# Altium schematic sheet RX.SchDoc(RX)
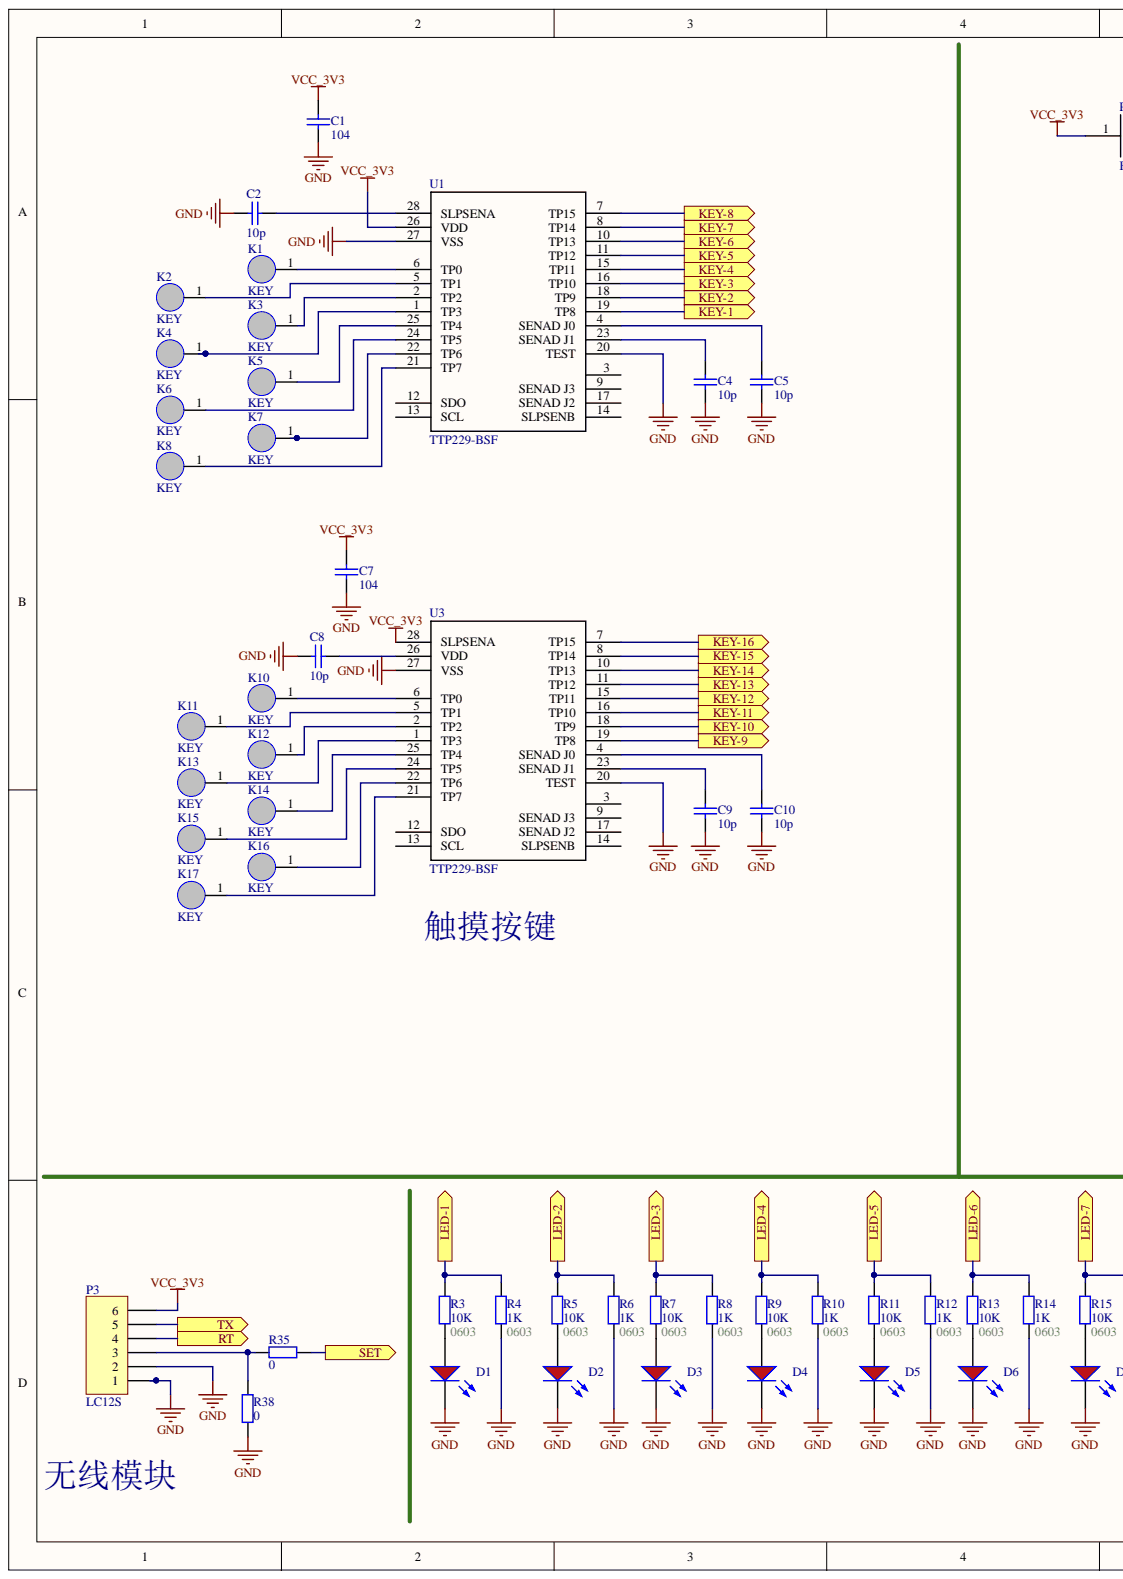
[diagram p0: RX.SchDoc, left]
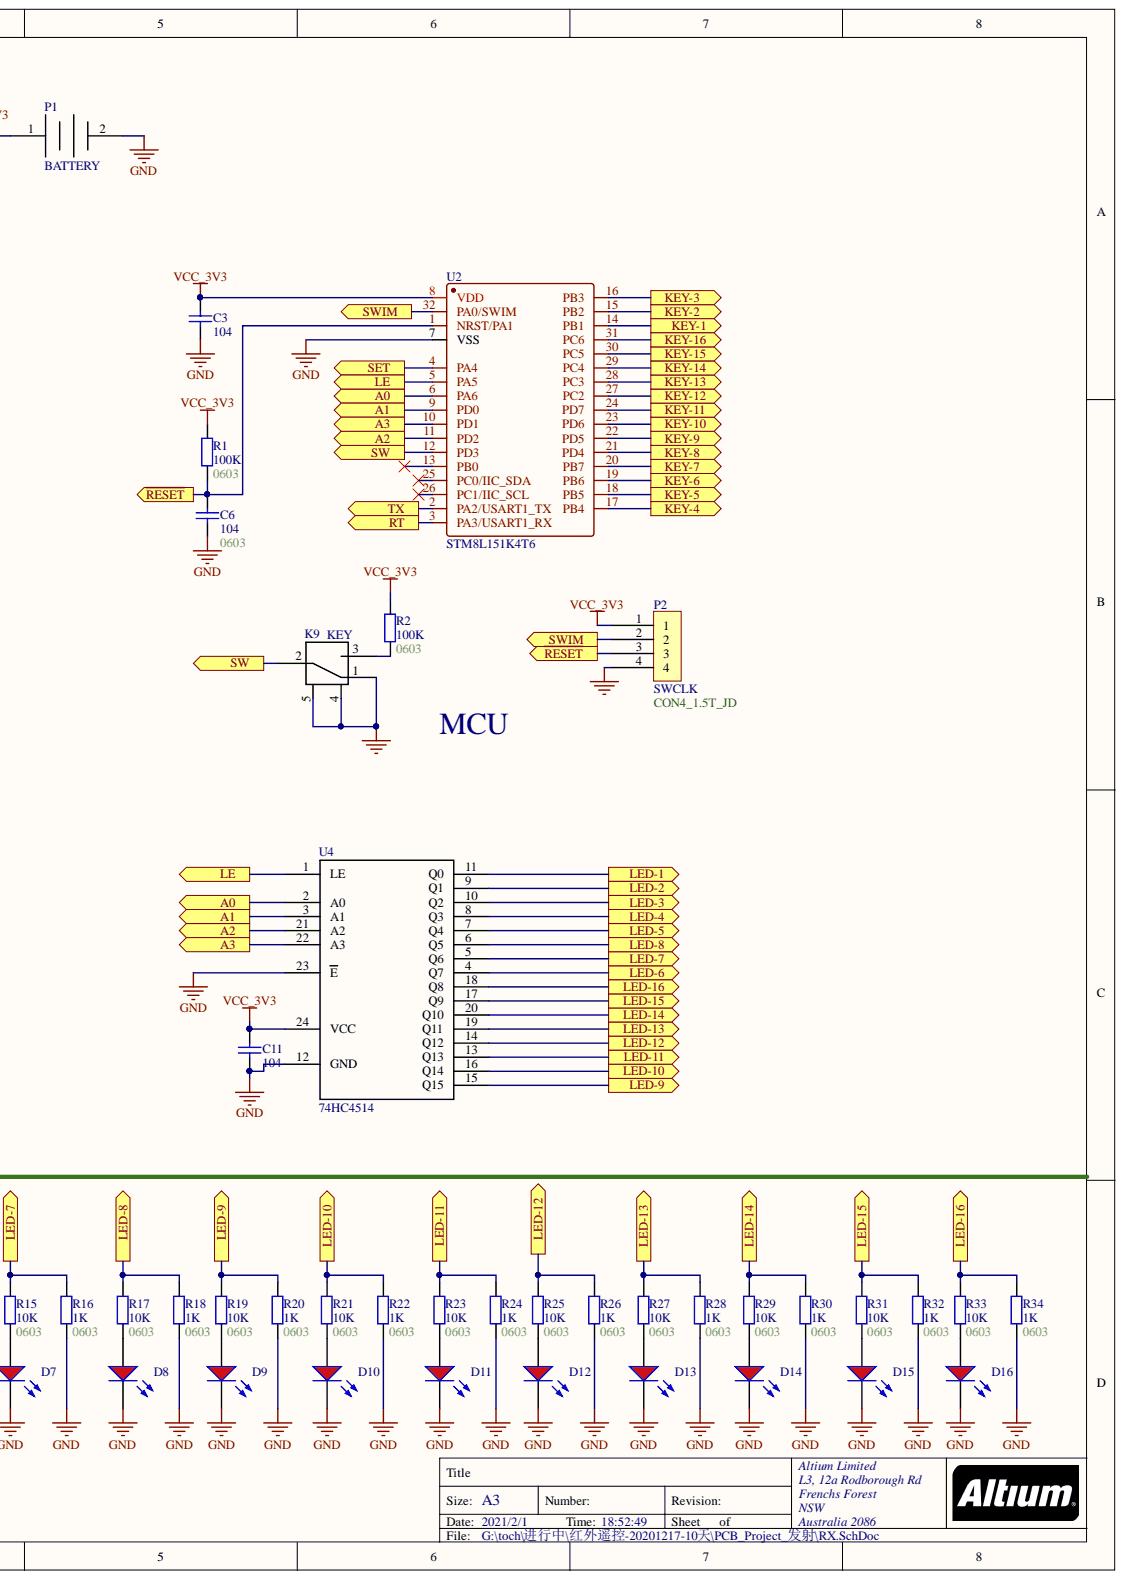
[diagram p1: RX.SchDoc, right]

## Parts
C1  104  [0603_C]
C2  10p  [0603_C]
C3  104  [0603_C]
C4  10p  [0603_C]
C5  10p  [0603_C]
C6  104  Package: 0603  [0603_C]
C7  104  [0603_C]
C8  10p  [0603_C]
C9  10p  [0603_C]
C10  10p  [0603_C]
C11  104  [0603_C]
D1  Package: 0603  [0603_LED_S1]  (hidden comment: 红灯 0603)
D2  Package: 0603  [0603_LED_S1]  (hidden comment: 红灯 0603)
D3  Package: 0603  [0603_LED_S1]  (hidden comment: 红灯 0603)
D4  Package: 0603  [0603_LED_S1]  (hidden comment: 红灯 0603)
D5  Package: 0603  [0603_LED_S1]  (hidden comment: 红灯 0603)
D6  Package: 0603  [0603_LED_S1]  (hidden comment: 红灯 0603)
D7  Package: 0603  [0603_LED_S1]  (hidden comment: 红灯 0603)
D8  Package: 0603  [0603_LED_S1]  (hidden comment: 红灯 0603)
D9  Package: 0603  [0603_LED_S1]  (hidden comment: 红灯 0603)
D10  Package: 0603  [0603_LED_S1]  (hidden comment: 红灯 0603)
D11  Package: 0603  [0603_LED_S1]  (hidden comment: 红灯 0603)
D12  Package: 0603  [0603_LED_S1]  (hidden comment: 红灯 0603)
D13  Package: 0603  [0603_LED_S1]  (hidden comment: 红灯 0603)
D14  Package: 0603  [0603_LED_S1]  (hidden comment: 红灯 0603)
D15  Package: 0603  [0603_LED_S1]  (hidden comment: 红灯 0603)
D16  Package: 0603  [0603_LED_S1]  (hidden comment: 红灯 0603)
K1  KEY  [key]
K2  KEY  [key]
K3  KEY  [key]
K4  KEY  [key]
K5  KEY  [key]
K6  KEY  [key]
K7  KEY  [key]
K8  KEY  [key]
K9  KEY  [SW]
K10  KEY  [key]
K11  KEY  [key]
K12  KEY  [key]
K13  KEY  [key]
K14  KEY  [key]
K15  KEY  [key]
K16  KEY  [key]
K17  KEY  [key]
P1  BATTERY  [2032]
P2  SWCLK  [xiazai]
P3  LC12S  [HDR1X6]
R1  100K  Package: 0603  [0603_R]
R2  100K  Package: 0603  [0603_R]
R3  10K  Package: 0603  [0603_R]
R4  1K  Package: 0603  [0603_R]
R5  10K  Package: 0603  [0603_R]
R6  1K  Package: 0603  [0603_R]
R7  10K  Package: 0603  [0603_R]
R8  1K  Package: 0603  [0603_R]
R9  10K  Package: 0603  [0603_R]
R10  1K  Package: 0603  [0603_R]
R11  10K  Package: 0603  [0603_R]
R12  1K  Package: 0603  [0603_R]
R13  10K  Package: 0603  [0603_R]
R14  1K  Package: 0603  [0603_R]
R15  10K  Package: 0603  [0603_R]
R16  1K  Package: 0603  [0603_R]
R17  10K  Package: 0603  [0603_R]
R18  1K  Package: 0603  [0603_R]
R19  10K  Package: 0603  [0603_R]
R20  1K  Package: 0603  [0603_R]
R21  10K  Package: 0603  [0603_R]
R22  1K  Package: 0603  [0603_R]
R23  10K  Package: 0603  [0603_R]
R24  1K  Package: 0603  [0603_R]
R25  10K  Package: 0603  [0603_R]
R26  1K  Package: 0603  [0603_R]
R27  10K  Package: 0603  [0603_R]
R28  1K  Package: 0603  [0603_R]
R29  10K  Package: 0603  [0603_R]
R30  1K  Package: 0603  [0603_R]
R31  10K  Package: 0603  [0603_R]
R32  1K  Package: 0603  [0603_R]
R33  10K  Package: 0603  [0603_R]
R34  1K  Package: 0603  [0603_R]
R35  0  [0603_R]
R38  0  [0603_R]
U1  TTP229-BSF  [TTP229-BSF]
U2  STM8L151K4T6  [STM8L151K4T6]
U3  TTP229-BSF  [TTP229-BSF]
U4  74HC4514  [74HC451]

## Ports
A0, A1, A2, A3, KEY-1, KEY-2, KEY-3, KEY-4, KEY-5, KEY-6, KEY-7, KEY-8, KEY-9, KEY-10, KEY-11, KEY-12, KEY-13, KEY-14, KEY-15, KEY-16, LE, LED-1, LED-2, LED-3, LED-4, LED-5, LED-6, LED-7, LED-8, LED-9, LED-10, LED-11, LED-12, LED-13, LED-14, LED-15, LED-16, RT, SET, SW, SWIM, TX, ~{RESET}

## Nets
GND: C1.1 C2.1 C3.1 C4.1 C5.1 C6.1 C7.1 C8.1 C9.1 C10.1 C11.1 D1.K D2.K D3.K D4.K D5.K D6.K D7.K D8.K D9.K D10.K D11.K D12.K D13.K D14.K D15.K D16.K K9.1 K9.4 K9.5 P1.2 P2.4 P3.1 P3.2 R4.1 R6.1 R8.1 R10.1 R12.1 R14.1 R16.1 R18.1 R20.1 R22.1 R24.1 R26.1 R28.1 R30.1 R32.1 R34.1 R38.1 U1.20(TEST) U1.27(VSS) U2.7(VSS) U3.20(TEST) U3.27(VSS) U4.12(GND) U4.23(E)
VCC_3V3: C1.2 C3.2 C7.2 C11.2 P1.1 P2.1 P3.6 R1.2 R2.2 U1.26(VDD) U2.8(VDD) U3.28(SLPSENA) U4.24(VCC)
A0: U2.6(PA6) U4.2(A0) ; port A0
A1: U2.9(PD0) U4.3(A1) ; port A1
A2: U2.11(PD2) U4.21(A2) ; port A2
A3: U2.10(PD1) U4.22(A3) ; port A3
KEY-1: U1.19(TP8) U2.14(PB1) ; port KEY-1
KEY-2: U1.18(TP9) U2.15(PB2) ; port KEY-2
KEY-3: U1.16(TP10) U2.16(PB3) ; port KEY-3
KEY-4: U1.15(TP11) U2.17(PB4) ; port KEY-4
KEY-5: U1.11(TP12) U2.18(PB5) ; port KEY-5
KEY-6: U1.10(TP13) U2.19(PB6) ; port KEY-6
KEY-7: U1.8(TP14) U2.20(PB7) ; port KEY-7
KEY-8: U1.7(TP15) U2.21(PD4) ; port KEY-8
KEY-9: U2.22(PD5) U3.19(TP8) ; port KEY-9
KEY-10: U2.23(PD6) U3.18(TP9) ; port KEY-10
KEY-11: U2.24(PD7) U3.16(TP10) ; port KEY-11
KEY-12: U2.27(PC2) U3.15(TP11) ; port KEY-12
KEY-13: U2.28(PC3) U3.11(TP12) ; port KEY-13
KEY-14: U2.29(PC4) U3.10(TP13) ; port KEY-14
KEY-15: U2.30(PC5) U3.8(TP14) ; port KEY-15
KEY-16: U2.31(PC6) U3.7(TP15) ; port KEY-16
LE: U2.5(PA5) U4.1(LE) ; port LE
LED-1: R3.2 R4.2 U4.11(Q0) ; port LED-1
LED-2: R5.2 R6.2 U4.9(Q1) ; port LED-2
LED-3: R7.2 R8.2 U4.10(Q2) ; port LED-3
LED-4: R9.2 R10.2 U4.8(Q3) ; port LED-4
LED-5: R11.2 R12.2 U4.7(Q4) ; port LED-5
LED-6: R13.2 R14.2 U4.4(Q7) ; port LED-6
LED-7: R15.2 R16.2 U4.5(Q6) ; port LED-7
LED-8: R17.2 R18.2 U4.6(Q5) ; port LED-8
LED-9: R19.2 R20.2 U4.15(Q15) ; port LED-9
LED-10: R21.2 R22.2 U4.16(Q14) ; port LED-10
LED-11: R23.2 R24.2 U4.13(Q13) ; port LED-11
LED-12: R25.2 R26.2 U4.14(Q12) ; port LED-12
LED-13: R27.2 R28.2 U4.19(Q11) ; port LED-13
LED-14: R29.2 R30.2 U4.20(Q10) ; port LED-14
LED-15: R31.2 R32.2 U4.17(Q9) ; port LED-15
LED-16: R33.2 R34.2 U4.18(Q8) ; port LED-16
~{RESET}: C6.2 P2.3 R1.1 U2.1(NRST/PA1) ; port ~{RESET}
RT: P3.4 U2.3(PA3/USART1_RX) ; port RT
SET: R35.2 U2.4(PA4) ; port SET
SW: K9.2 U2.12(PD3) ; port SW
SWIM: P2.2 U2.32(PA0/SWIM) ; port SWIM
TX: P3.5 U2.2(PA2/USART1_TX) ; port TX
NetC2_2: C2.2 U1.28(SLPSENA)
NetC4_2: C4.2 U1.23(SENAD_J1)
NetC5_2: C5.2 U1.4(SENAD_J0)
NetC8_2: C8.2 U3.26(VDD)
NetC9_2: C9.2 U3.23(SENAD_J1)
NetC10_2: C10.2 U3.4(SENAD_J0)
NetD1_A: D1.A R3.1
NetD2_A: D2.A R5.1
NetD3_A: D3.A R7.1
NetD4_A: D4.A R9.1
NetD5_A: D5.A R11.1
NetD6_A: D6.A R13.1
NetD7_A: D7.A R15.1
NetD8_A: D8.A R17.1
NetD9_A: D9.A R19.1
NetD10_A: D10.A R21.1
NetD11_A: D11.A R23.1
NetD12_A: D12.A R25.1
NetD13_A: D13.A R27.1
NetD14_A: D14.A R29.1
NetD15_A: D15.A R31.1
NetD16_A: D16.A R33.1
NetK1_1: K1.1 U1.6(TP0)
NetK2_1: K2.1 U1.5(TP1)
NetK3_1: K3.1 U1.2(TP2)
NetK4_1: K4.1 U1.1(TP3)
NetK5_1: K5.1 U1.25(TP4)
NetK6_1: K6.1 U1.24(TP5)
NetK7_1: K7.1 U1.22(TP6)
NetK8_1: K8.1 U1.21(TP7)
NetK9_3: K9.3 R2.1
NetK10_1: K10.1 U3.6(TP0)
NetK11_1: K11.1 U3.5(TP1)
NetK12_1: K12.1 U3.2(TP2)
NetK13_1: K13.1 U3.1(TP3)
NetK14_1: K14.1 U3.25(TP4)
NetK15_1: K15.1 U3.24(TP5)
NetK16_1: K16.1 U3.22(TP6)
NetK17_1: K17.1 U3.21(TP7)
NetP3_3: P3.3 R35.1 R38.2

Not connected: U1 3 9(SENAD_J3) 12(SDO) 13(SCL) 14(SLPSENB) 17(SENAD_J2); U2 13(PB0) 25(PC0/IIC_SDA) 26(PC1/IIC_SCL); U3 3 9(SENAD_J3) 12(SDO) 13(SCL) 14(SLPSENB) 17(SENAD_J2)

## Library data of the parts
C1 C2 C4 C5 C7-C11 = 0603 100nF (104) 10% 50V: 贴片电容; SuppliersPartNumber: C14663; Supplier: LC; Package: 0603; Library Name: Miscellaneous Devices LC.IntLib; ComponentLink1URL: http://www.szlcsc.com/product/details_15331.html; ComponentLink1Description: 供应商链接; Component Kind: Standard
C3 = 0603 10uF (106) 10% 10V: 贴片电容; SuppliersPartNumber: C19702; Supplier: LC; Package: 0603; Library Name: Miscellaneous Devices LC.IntLib; ComponentLink1URL: http://www.szlcsc.com/product/details_20411.html; ComponentLink1Description: 供应商链接; Component Kind: Standard
C6 = 0603 1uF (105) 10% 50V: 贴片电容; SuppliersPartNumber: C15849; Supplier: LC; Library Name: Miscellaneous Devices LC.IntLib; ComponentLink1URL: http://www.szlcsc.com/product/details_16531.html; ComponentLink1Description: 供应商链接; Component Kind: Standard
D1-D16 = 0603 红灯: 发光二极管; SuppliersPartNumber: C2286; Supplier: LC; Library Name: Miscellaneous Devices LC.IntLib; ComponentLink1URL: http://www.szlcsc.com/product/details_2659.html; ComponentLink1Description: 供应商链接; Component Kind: Standard
P2 = SIP_4: CON4_1.5T_JD; Parameter 1: CON4_1.5T_JD; Component Kind: Standard; Library Name: CONNECTER.SchLib
P3 = Header 6: Header, 6-Pin; Signal Integrity: Connector; Library Name: Miscellaneous Connectors.IntLib; LatestRevisionNote: Re-released for DXP Platform.; LatestRevisionDate: 17-Jul-2002; Component Kind: Standard; Publisher: Altium Limited
R1-R34 = 0603 100KΩ (1003) 1%: 贴片电阻; SuppliersPartNumber: C25803; Supplier: LC; Library Name: Miscellaneous Devices LC.IntLib; ComponentLink1URL: http://www.szlcsc.com/product/details_26546.html; ComponentLink1Description: 供应商链接; Component Kind: Standard
R35 R38 = 0603 0Ω (0R0) 1%: 贴片电阻; SuppliersPartNumber: C21189; Supplier: LC; Package: 0603; Library Name: Miscellaneous Devices LC.IntLib; ComponentLink1URL: http://www.szlcsc.com/product/details_21903.html; ComponentLink1Description: 供应商链接; Component Kind: Standard
K1-K17 = KEY: Library Name: Schlib1.SchLib; Component Kind: Standard
P1 = BATTERY: Library Name: Schlib1.SchLib; Component Kind: Standard
U1 U3 = TTP229-BSF: Library Name: Schlib1.SchLib; Component Kind: Standard
U2 = STM8L151K4T6: Library Name: Schlib1.SchLib; Component Kind: Standard
U4 = 74HC4514: Library Name: Schlib1.SchLib; Component Kind: Standard
